# Altium schematic sheet 音乐频谱分析仪2014-11-17.SchDoc(音乐频谱分析仪2014-11-17)
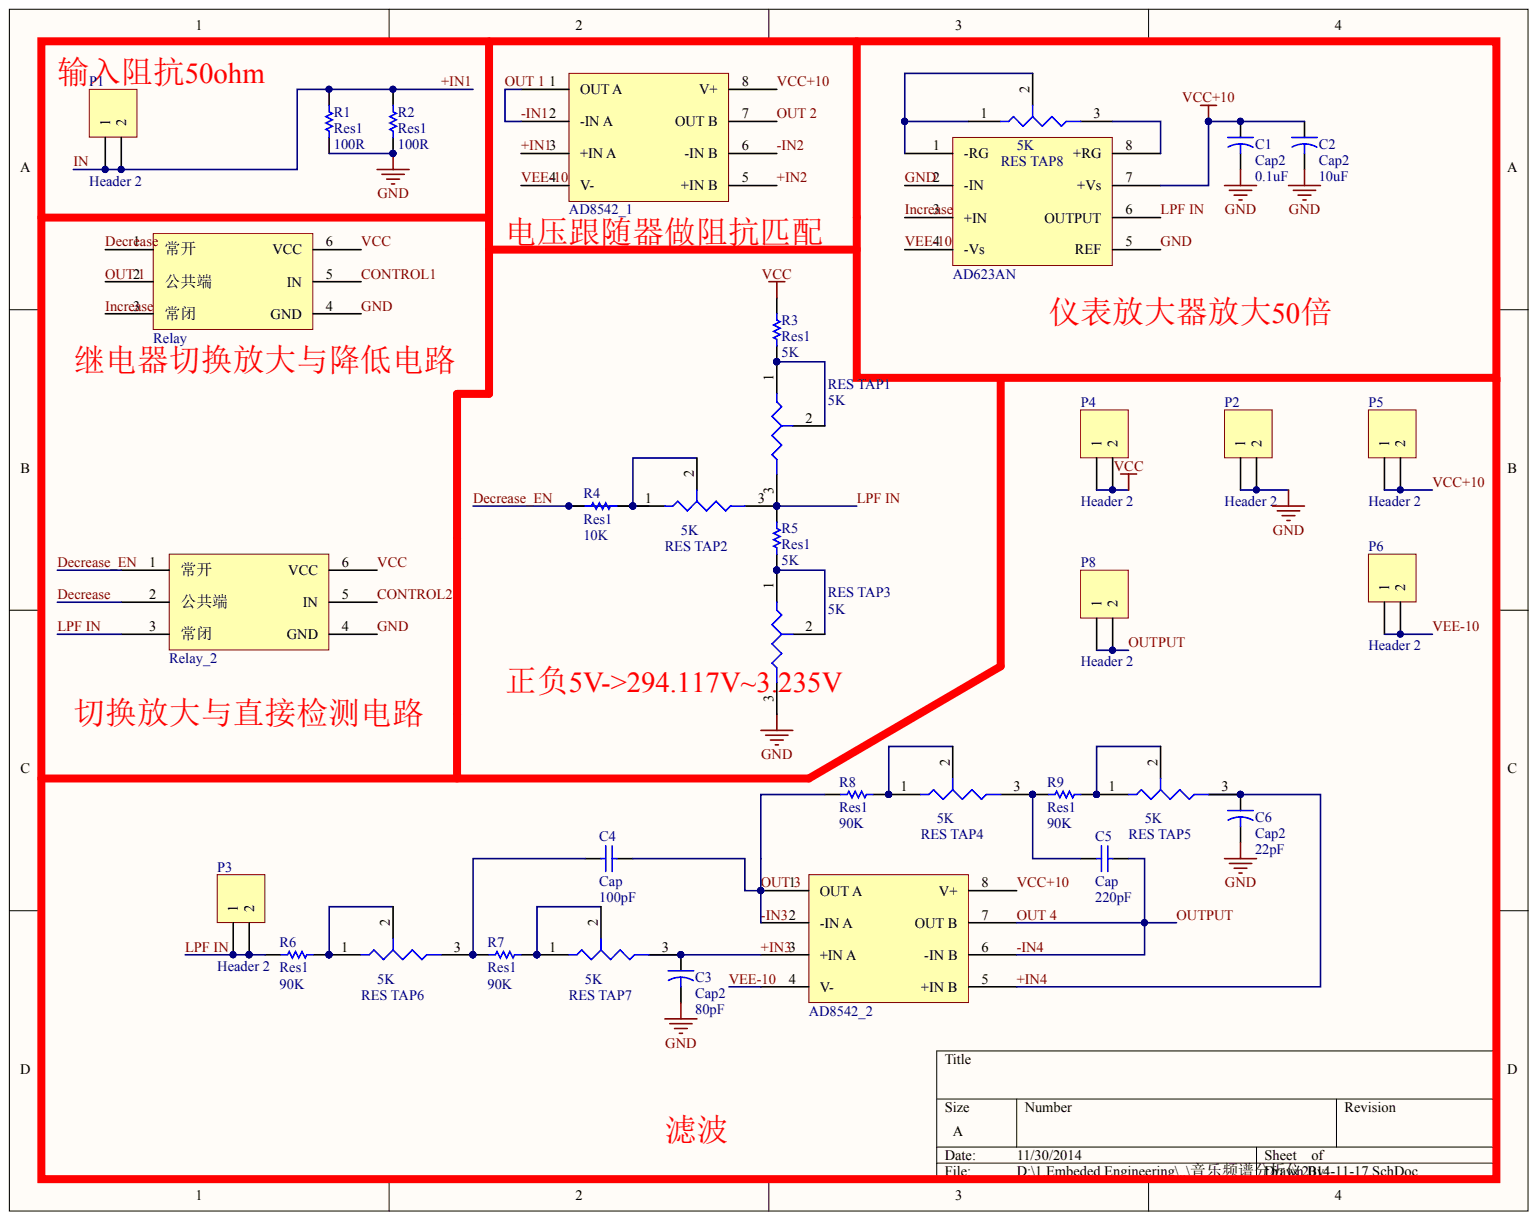
[diagram p0: 音乐频谱分析仪2014-11-17.SchDoc]

## Parts
AD623AN  AD623AN
AD8542_1  AD8542
AD8542_2  AD8542
C1  Cap2  value 0.1uF
C2  Cap2  value 10uF
C3  Cap2  value 80pF
C4  Cap  value 100pF
C5  Cap  value 220pF
C6  Cap2  value 22pF
P1  Header 2
P2  Header 2
P3  Header 2
P4  Header 2
P5  Header 2
P6  Header 2
P8  Header 2
R1  Res1  value 100R
R2  Res1  value 100R
R3  Res1  value 5K
R4  Res1  value 10K
R5  Res1  value 5K
R6  Res1  value 90K
R7  Res1  value 90K
R8  Res1  value 90K
R9  Res1  value 90K
Relay  Relay
Relay_2  Relay
RES_TAP1  5K
RES_TAP2  5K
RES_TAP3  5K
RES_TAP4  5K
RES_TAP5  5K
RES_TAP6  5K
RES_TAP7  5K
RES_TAP8  5K

## Nets
+IN1: AD8542_1.3(+IN_A) P1.1 P1.2 R1.2 R2.2
+IN2: AD8542_1.5(+IN_B)
+IN3: AD8542_2.3(+IN_A) C3.1 RES_TAP7.3
+IN4: AD8542_2.5(+IN_B) C6.1 RES_TAP5.3
-IN1: AD8542_1.1(OUT_A) AD8542_1.2(-IN_A) Relay.2(公共端)
-IN2: AD8542_1.6(-IN_B)
-IN3: AD8542_2.1(OUT_A) AD8542_2.2(-IN_A) C4.2 R8.2
-IN4: AD8542_2.6(-IN_B) AD8542_2.7(OUT_B) C5.2 P8.1 P8.2
CONTROL1: Relay.5(IN)
CONTROL2: Relay_2.5(IN)
DECREASE: Relay.1(常开) Relay_2.2(公共端)
DECREASE_EN: R4.1 R4.2 Relay_2.1(常开) RES_TAP2.1 RES_TAP2.2
GND: AD623AN.2(-IN) AD623AN.5(REF) C1.2 C2.2 C3.2 C6.2 P2.1 P2.2 R1.1 R2.1 Relay.4(GND) Relay_2.4(GND) RES_TAP3.3
INCREASE: AD623AN.3(+IN) Relay.3(常闭)
LPF IN: AD623AN.6(OUTPUT) P3.1 P3.2 R5.2 R6.2 Relay_2.3(常闭) RES_TAP1.3 RES_TAP2.3
OUT 2: AD8542_1.7(OUT_B)
VCC: P4.1 P4.2 R3.2 Relay.6(VCC) Relay_2.6(VCC)
VCC+10: AD623AN.7(+Vs) AD8542_1.8(V+) AD8542_2.8(V+) C1.1 C2.1 P5.1 P5.2
VEE-10: AD623AN.4(-Vs) AD8542_1.4(V-) AD8542_2.4(V-) P6.1 P6.2
NetAD623AN_1: AD623AN.1(-RG) RES_TAP8.1 RES_TAP8.2
NetAD623AN_8: AD623AN.8(+RG) RES_TAP8.3
NetC4_1: C4.1 R7.2 RES_TAP6.3
NetC5_1: C5.1 R9.2 RES_TAP4.3
NetR3_1: R3.1 RES_TAP1.1 RES_TAP1.2
NetR5_1: R5.1 RES_TAP3.1 RES_TAP3.2
NetR6_1: R6.1 RES_TAP6.1 RES_TAP6.2
NetR7_1: R7.1 RES_TAP7.1 RES_TAP7.2
NetR8_1: R8.1 RES_TAP4.1 RES_TAP4.2
NetR9_1: R9.1 RES_TAP5.1 RES_TAP5.2

## Library data of the parts
C4 = (no symbol name): Value: 100pF; Published: 8-Jun-2000; LatestRevisionDate: 17-Jul-2002; LatestRevisionNote: Re-released for DXP Platform.; PackageReference: RAD-0.3; PackageDescription: Radial Cap, Thru-Hole; 2 Leads; 0.3 in Pin Spacing; Publisher: Altium Limited
AD623AN AD8542_1 AD8542_2 C1-C3 C5 C6 P1-P6 P8 R1-R9 Relay Relay_2 RES_TAP1-RES_TAP8 = (no symbol name)
  C1-C3 C6: Published: 23-Sep-2002; PackageReference: CAPR5-4X5; PackageDescription: Capacitor; 2 Leads
  C5: Published: 8-Jun-2000; LatestRevisionDate: 17-Jul-2002; LatestRevisionNote: Re-released for DXP Platform.; PackageReference: RAD-0.3; PackageDescription: Radial Cap, Thru-Hole; 2 Leads; 0.3 in Pin Spacing
  P1-P6 P8: LatestRevisionDate: 17-Jul-2002; LatestRevisionNote: Re-released for DXP Platform.
  R1-R9: Published: 8-Jun-2000; LatestRevisionDate: 17-Jul-2002; LatestRevisionNote: Re-released for DXP Platform.; PackageReference: AXIAL-0.3; PackageDescription: Axial Device, Thru-Hole; 2 Leads; 0.3 in Pin Spacing
  C1-C3 C5 C6 P1-P6 P8 R1-R9: Publisher: Altium Limited
